# Altium schematic sheet SDRAM.SchDoc
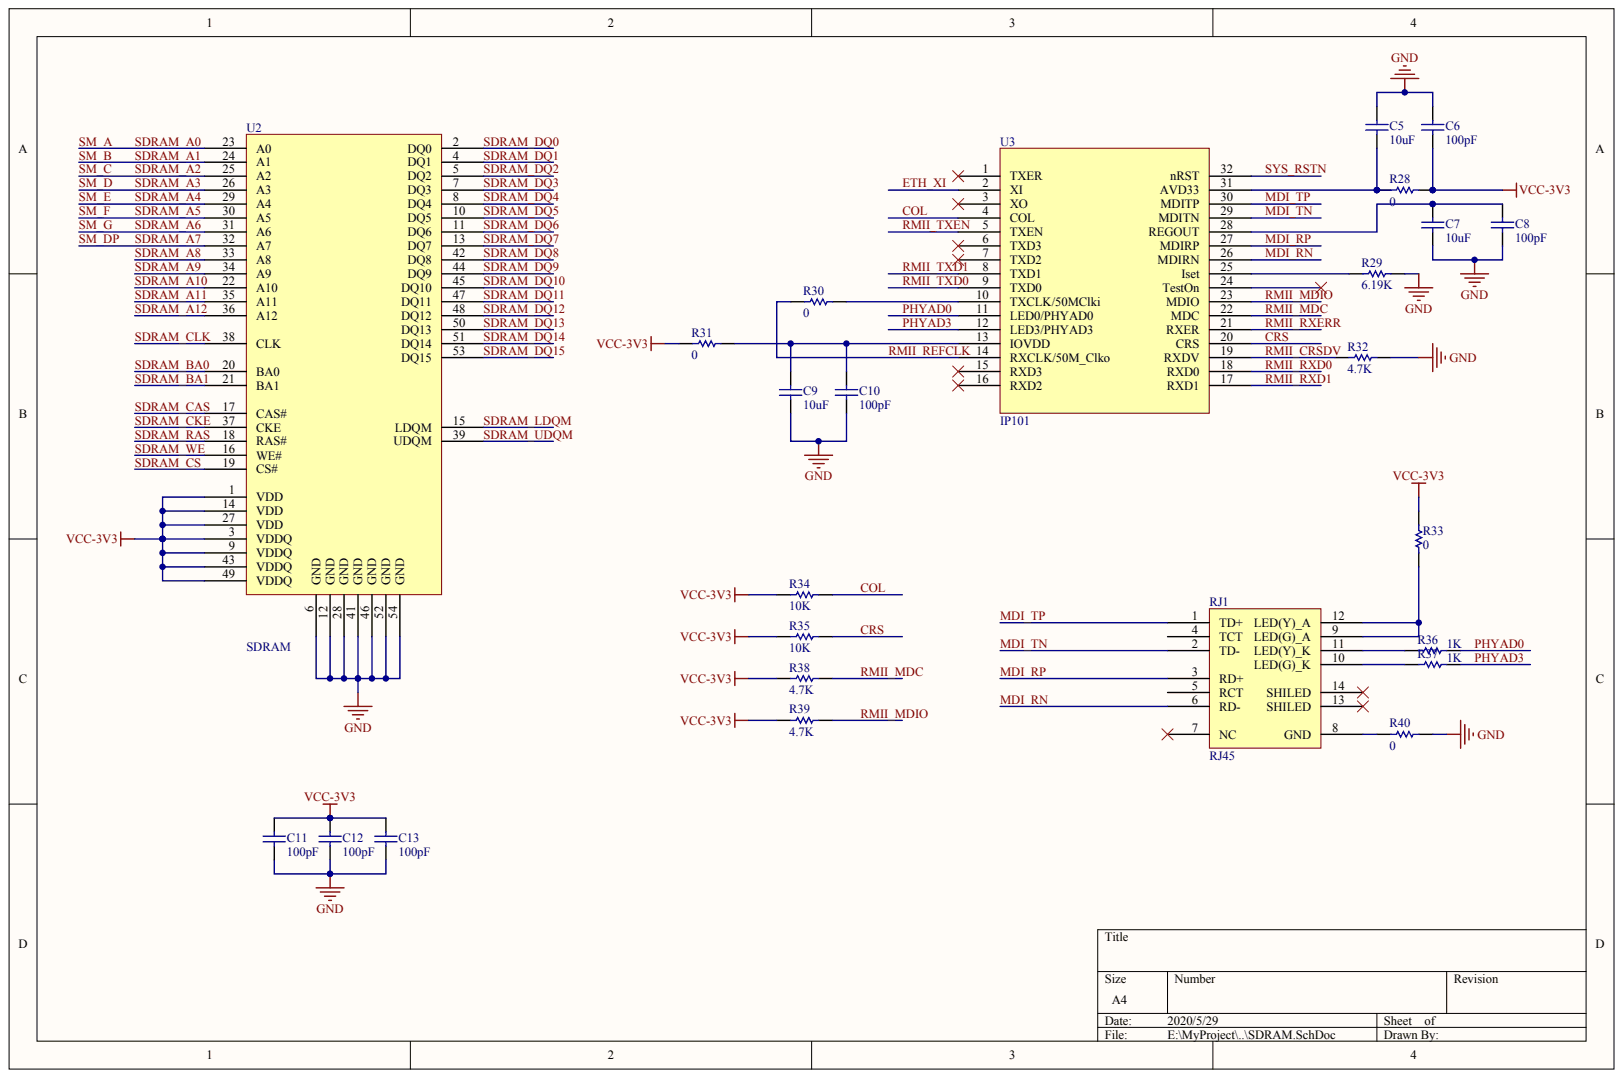
[diagram p0: SDRAM.SchDoc]

## Parts
C5  value 10uF  (hidden comment: Cap)
C6  value 100pF  (hidden comment: Cap)
C7  value 10uF  (hidden comment: Cap)
C8  value 100pF  (hidden comment: Cap)
C9  value 10uF  (hidden comment: Cap)
C10  value 100pF  (hidden comment: Cap)
C11  value 100pF  (hidden comment: Cap)
C12  value 100pF  (hidden comment: Cap)
C13  value 100pF  (hidden comment: Cap)
R28  value 0  (hidden comment: Res1)
R29  value 6.19K  (hidden comment: Res1)
R30  value 0  (hidden comment: Res1)
R31  value 0  (hidden comment: Res1)
R32  value 4.7K  (hidden comment: Res1)
R33  value 0  (hidden comment: Res1)
R34  value 10K  (hidden comment: Res1)
R35  value 10K  (hidden comment: Res1)
R36  value 1K  (hidden comment: Res1)
R37  value 1K  (hidden comment: Res1)
R38  value 4.7K  (hidden comment: Res1)
R39  value 4.7K  (hidden comment: Res1)
R40  value 0  (hidden comment: Res1)
RJ1  RJ45
U2  SDRAM
U3  IP101

## Nets
GND: C5.2 C6.2 C7.1 C8.1 C9.1 C10.1 C11.1 C12.1 C13.1 R29.2 R32.2 R40.1 U2.6(GND) U2.12(GND) U2.28(GND) U2.41(GND) U2.46(GND) U2.52(GND) U2.54(GND)
VCC-3V3: C6.1 C11.2 C12.2 C13.2 R28.2 R31.1 R33.2 R34.2 R35.2 R38.2 R39.2 U2.1(VDD) U2.3(VDDQ) U2.9(VDDQ) U2.14(VDD) U2.27(VDD) U2.43(VDDQ) U2.49(VDDQ)
COL: R34.1 U3.4(COL)
CRS: R35.1 U3.20(CRS)
ETH_XI: U3.2(XI)
MDI_RN: RJ1.6(RD-) U3.26(MDIRN)
MDI_RP: RJ1.3(RD+) U3.27(MDIRP)
MDI_TN: RJ1.2(TD-) U3.29(MDITN)
MDI_TP: RJ1.1(TD+) U3.30(MDITP)
PHYAD0: R36.1 U3.11(LED0/PHYAD0)
PHYAD3: R37.1 U3.12(LED3/PHYAD3)
RMII_CRSDV: R32.1 U3.19(RXDV)
RMII_MDC: R38.1 U3.22(MDC)
RMII_MDIO: R39.1 U3.23(MDIO)
RMII_REFCLK: R30.1 U3.14(RXCLK/50M_Clko)
RMII_RXD0: U3.18(RXD0)
RMII_RXD1: U3.17(RXD1)
RMII_RXERR: U3.21(RXER)
RMII_TXD0: U3.9(TXD0)
RMII_TXD1: U3.8(TXD1)
RMII_TXEN: U3.5(TXEN)
SDRAM_A0: U2.23(A0)
SDRAM_A1: U2.24(A1)
SDRAM_A2: U2.25(A2)
SDRAM_A3: U2.26(A3)
SDRAM_A4: U2.29(A4)
SDRAM_A5: U2.30(A5)
SDRAM_A6: U2.31(A6)
SDRAM_A7: U2.32(A7)
SDRAM_A8: U2.33(A8)
SDRAM_A9: U2.34(A9)
SDRAM_A10: U2.22(A10)
SDRAM_A11: U2.35(A11)
SDRAM_A12: U2.36(A12)
SDRAM_BA0: U2.20(BA0)
SDRAM_BA1: U2.21(BA1)
SDRAM_CAS: U2.17(CAS#)
SDRAM_CKE: U2.37(CKE)
SDRAM_CLK: U2.38(CLK)
SDRAM_CS: U2.19(CS#)
SDRAM_DQ0: U2.2(DQ0)
SDRAM_DQ1: U2.4(DQ1)
SDRAM_DQ2: U2.5(DQ2)
SDRAM_DQ3: U2.7(DQ3)
SDRAM_DQ4: U2.8(DQ4)
SDRAM_DQ5: U2.10(DQ5)
SDRAM_DQ6: U2.11(DQ6)
SDRAM_DQ7: U2.13(DQ7)
SDRAM_DQ8: U2.42(DQ8)
SDRAM_DQ9: U2.44(DQ9)
SDRAM_DQ10: U2.45(DQ10)
SDRAM_DQ11: U2.47(DQ11)
SDRAM_DQ12: U2.48(DQ12)
SDRAM_DQ13: U2.50(DQ13)
SDRAM_DQ14: U2.51(DQ14)
SDRAM_DQ15: U2.53(DQ15)
SDRAM_LDQM: U2.15(LDQM)
SDRAM_RAS: U2.18(RAS#)
SDRAM_UDQM: U2.39(UDQM)
SDRAM_WE: U2.16(WE#)
SYS_RSTN: U3.32(nRST)
NetC5_1: C5.1 R28.1 U3.31(AVD33)
NetC7_2: C7.2 C8.2 U3.28(REGOUT)
NetC9_2: C9.2 C10.2 R31.2 U3.13(IOVDD)
NetR29_1: R29.1 U3.25(Iset)
NetR30_2: R30.2 U3.10(TXCLK/50MClki)
NetR33_1: R33.1 RJ1.9(LED(G)_A) RJ1.12(LED(Y)_A)
NetR36_2: R36.2 RJ1.11(LED(Y)_K)
NetR37_2: R37.2 RJ1.10(LED(G)_K)
NetR40_2: R40.2 RJ1.8(GND)

Not connected: RJ1 4(TCT) 5(RCT) 7(NC) 13(SHILED) 14(SHILED); U3 1(TXER) 3(XO) 6(TXD3) 7(TXD2) 15(RXD3) 16(RXD2) 24(TestOn)

## Library data of the parts
C5-C13 R28-R40 RJ1 U2 U3 = (no symbol name)
  C5-C13: Published: 8-Jun-2000; LatestRevisionDate: 17-Jul-2002; LatestRevisionNote: Re-released for DXP Platform.; PackageReference: RAD-0.3; PackageDescription: Radial Cap, Thru-Hole; 2 Leads; 0.3 in Pin Spacing
  R28-R40: Published: 8-Jun-2000; LatestRevisionDate: 17-Jul-2002; LatestRevisionNote: Re-released for DXP Platform.; PackageReference: AXIAL-0.3; PackageDescription: Axial Device, Thru-Hole; 2 Leads; 0.3 in Pin Spacing
  C5-C13 R28-R40: Publisher: Altium Limited
